# Altium schematic sheet Magnetic_Encoder.SchDoc
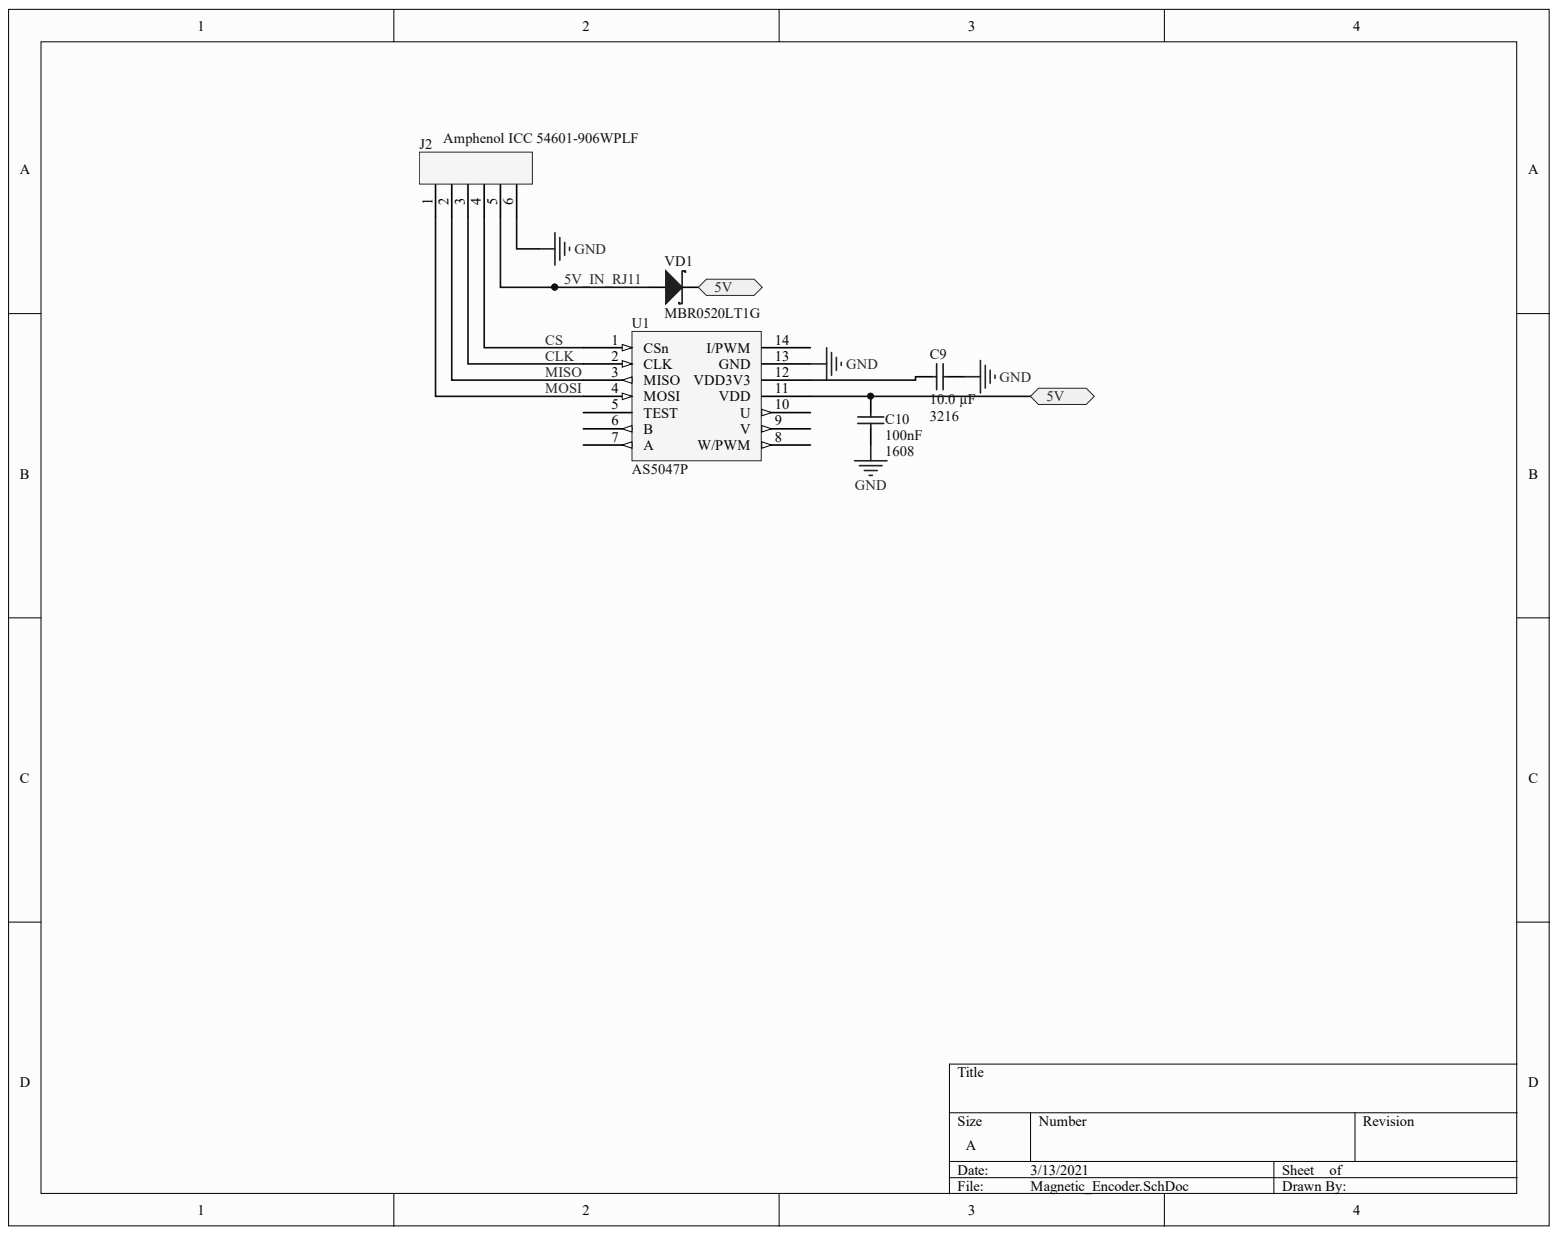
[diagram p0: Magnetic_Encoder.SchDoc]

## Parts
C9  Capacitance: 10.0 µF  Size: 3216  [High Density]  (hidden comment: Cap)
C10  100nF  Size: 1608  [CAPC1608X09N]
J2  Amphenol ICC 54601-906WPLF  [PCBComponent]
U1  AS5047P  [PCB-bn9a8dt4cg24clnvvkgl-1]
VD1  MBR0520LT1G  [SOD-123_B]

## Ports
5V

## Nets
GND: C9.1 C10.1 J2.6 U1.13(GND)
5V: C10.2 U1.11(VDD) VD1.1 ; port 5V
5V_IN_RJ11: J2.5 VD1.2
CLK: J2.3 U1.2(CLK)
CS: J2.4 U1.1(CSn)
MISO: J2.2 U1.3(MISO)
MOSI: J2.1 U1.4(MOSI)
NetC9_2: C9.2 U1.12(VDD3V3)

Not connected: U1 5(TEST) 6(B) 7(A) 8(W/PWM) 9(V) 10(U) 14(I/PWM)

## Library data of the parts
C9 = CMP-bac072e4006c6507-6: CL31 Series 10 uF 50 V ±10 % Tolerance X5R SMT Multilayer Ceramic Capacitor; Manufacturer Part Number: CL31A106KBHNNNE; Lead-Free Status: Lead Free; Voltage Rating (DC): 50.0 V; Component Kind: Standard; Library Name: CommunityVault; Mounting Style: Surface Mount; OctopartID: bac072e4006c6507
C10 = CMP-7555619-5: CL10 Series 0.1 uF 50 V ±5 % Tolerance X7R SMT Multilayer Ceramic Capacitor; Manufacturer Part Number: CL10B104JB8NNNC; Component Kind: Standard; Library Name: CommunityVault; Mounting Style: Surface Mount
J2 = CMP-bed072b8fb136117-3: Conn Modular Jack RCP 6 POS 2.54mm Solder RA Thru-Hole 6 Terminal 1 Port Cat 3 Box; Component Kind: Standard; Contact Plating: Gold; Library Name: CommunityVault; Mounting Style: Through Hole, Vertical
U1 = CMP-d38a190911520c4d-1: AS5047P Series 5.5 V 14-bit On-Axis Magnetic Rotary Position Sensor - TSSOP-14; Manufacturer Part Number: AS5047P-ATSM; Component Kind: Standard; Library Name: CommunityVault; OctopartID: d38a190911520c4d; Octopart URL: http://octopart.com/as5047p-atsm-ams-59369406; RoHS: Compliant
VD1 = CMP-3786467-11: Rectifier, Schottky Power; Plastic Sod-123; 0.5 a @ +90c If; +125; 20v; 1000; Current Rating: 0.5 A; Forward Voltage: 0.385 V; Lead-Free Status: Lead Free; Polarity: Standard; Voltage Rating (DC): 20.0 V; Case/Package: SOD-123; Component Kind: Standard; Library Name: CommunityVault; Lifecycle Status: Active; Manufacturer Part Number: MBR0520LT1G; Mounting Style: Surface Mount; Number of Pins: 2; OctopartID: 81934899af805e54; Octopart Url: http://octopart.com/mbr0520lt1g-on+semiconductor-2933; REACH SVHC Compliance: No SVHC; RoHS: Compliant; Seller Landing  Url: https://octopart-clicks.com/click/track?country=US&ak=8157f166&sig=02b8313&sid=5489&ppid=2933&vpid=120602749&ct=offers
